# Altium schematic sheet Sheet1.SchDoc(Sheet1)
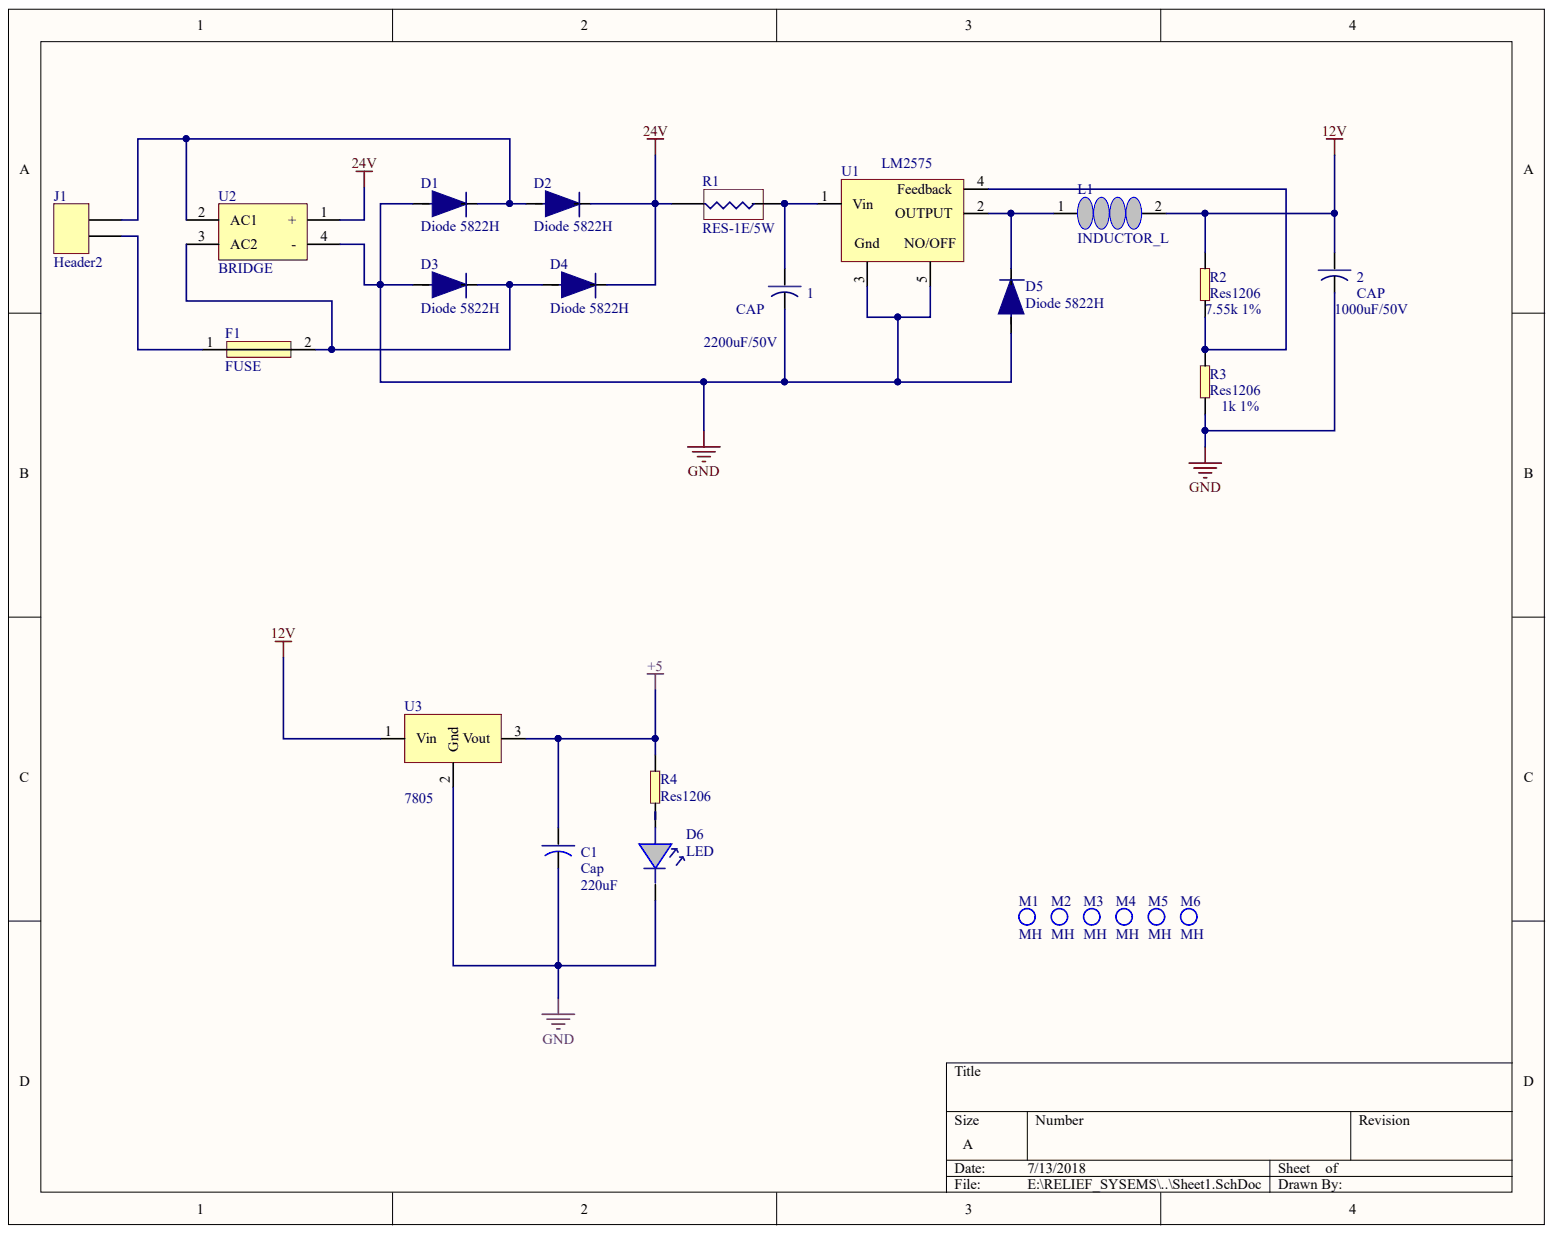
[diagram p0: Sheet1.SchDoc]

## Parts
1  CAP  value 2200uF/50V  [Capacitor - 2200uF]
2  CAP  value 1000uF/50V  [Capacitor1000uF]
C1  Cap  [CAP_220uF]
D1  Diode 5822H  [Diode]
D2  Diode 5822H  [Diode]
D3  Diode 5822H  [Diode]
D4  Diode 5822H  [Diode]
D5  Diode 5822H  [Diode]
D6  LED  [LED5MM]
F1  FUSE  [FUSE]
J1  Header2  [Header2]
L1  INDUCTOR_L  [inductor-200mil]
M1  MH  [Mounting hole]
M2  MH  [Mounting hole]
M3  MH  [Mounting hole]
M4  MH  [Mounting hole]
M5  MH  [Mounting hole]
M6  MH  [Mounting hole]
R1  RES-1E/5W  [RES-1E/5W]
R2  Res1206  value 7.55k 1%  [1206]
R3  Res1206  value 1k 1%  [1206]
R4  Res1206  [1206]
U1  LM2575  [D2PAK_5PIN]
U2  BRIDGE  [BRIDGE_200MIL]
U3  7805  [D-PAK]

## Nets
12V: 2.1 L1.2 R2.2 U3.1
24V: D2.2 D4.2 R1.1 U2.1(+)
+5: C1.1 R4.2 U3.3
GND: 1.2 2.2 C1.2 D1.1 D3.1 D5.1 D6.2 R3.1 U1.3 U1.5 U2.4(-) U3.2
Net1_1: 1.1 R1.2 U1.1(Vin)
NetD1_2: D1.2 D2.1 J1.2 U2.2(AC1)
NetD3_2: D3.2 D4.1 F1.2 U2.3(AC2)
NetD5_2: D5.2 L1.1 U1.2(OUTPUT)
NetD6_1: D6.1 R4.1
NetF1_1: F1.1 J1.1
NetR2_1: R2.1 R3.2 U1.4(Feedback)

## Library data of the parts
1 2 = 2200uF/50V: Component Kind: Standard; Library Name: VIJAYIntegrated_Library.IntLib
C1 = CAP_220uF: : 220uF; Component Kind: Standard; Description: Cap_220uF; Library Name: Dinesh_New_Integrated_Library.IntLib
D6 = LED_5MM: Component Kind: Standard; Description: LED_5MM; Library Name: Dinesh_New_Integrated_Library.IntLib
L1 = Inductor-200: Component Kind: Standard; Description: Inductor-200; Library Name: VIJAYIntegrated_Library.IntLib
U1 = LM2575: Vol Reg; Component Kind: Standard; Library Name: Dinesh_New_Integrated_Library.IntLib
U3 = 7805: Vol Reg; Component Kind: Standard; Library Name: Dinesh_New_Integrated_Library.IntLib
D1-D5 = Diode 5822H: Component Kind: Standard; Library Name: VIJAYIntegrated_Library.IntLib
F1 = FUSE: Component Kind: Standard; Description: Fuse; Library Name: Dinesh_New_Integrated_Library.IntLib
J1 = Header2: Component Kind: Standard; Library Name: VIJAYIntegrated_Library.IntLib
M1-M6 = MH: Component Kind: Standard; Library Name: Dinesh_New_Integrated_Library.IntLib
R1 = RES-1E/5W: Component Kind: Standard; Library Name: VIJAYIntegrated_Library.IntLib
R2-R4 = Res1206: Component Kind: Standard; Description: Res1206; Library Name: Dinesh_New_Integrated_Library.IntLib
U2 = BRIDGE: Component Kind: Standard; Description: BRIDGE; Library Name: Dinesh_New_Integrated_Library.IntLib
